# Altium schematic sheet kmd-foc[1].SchDoc(kmd-foc[1])
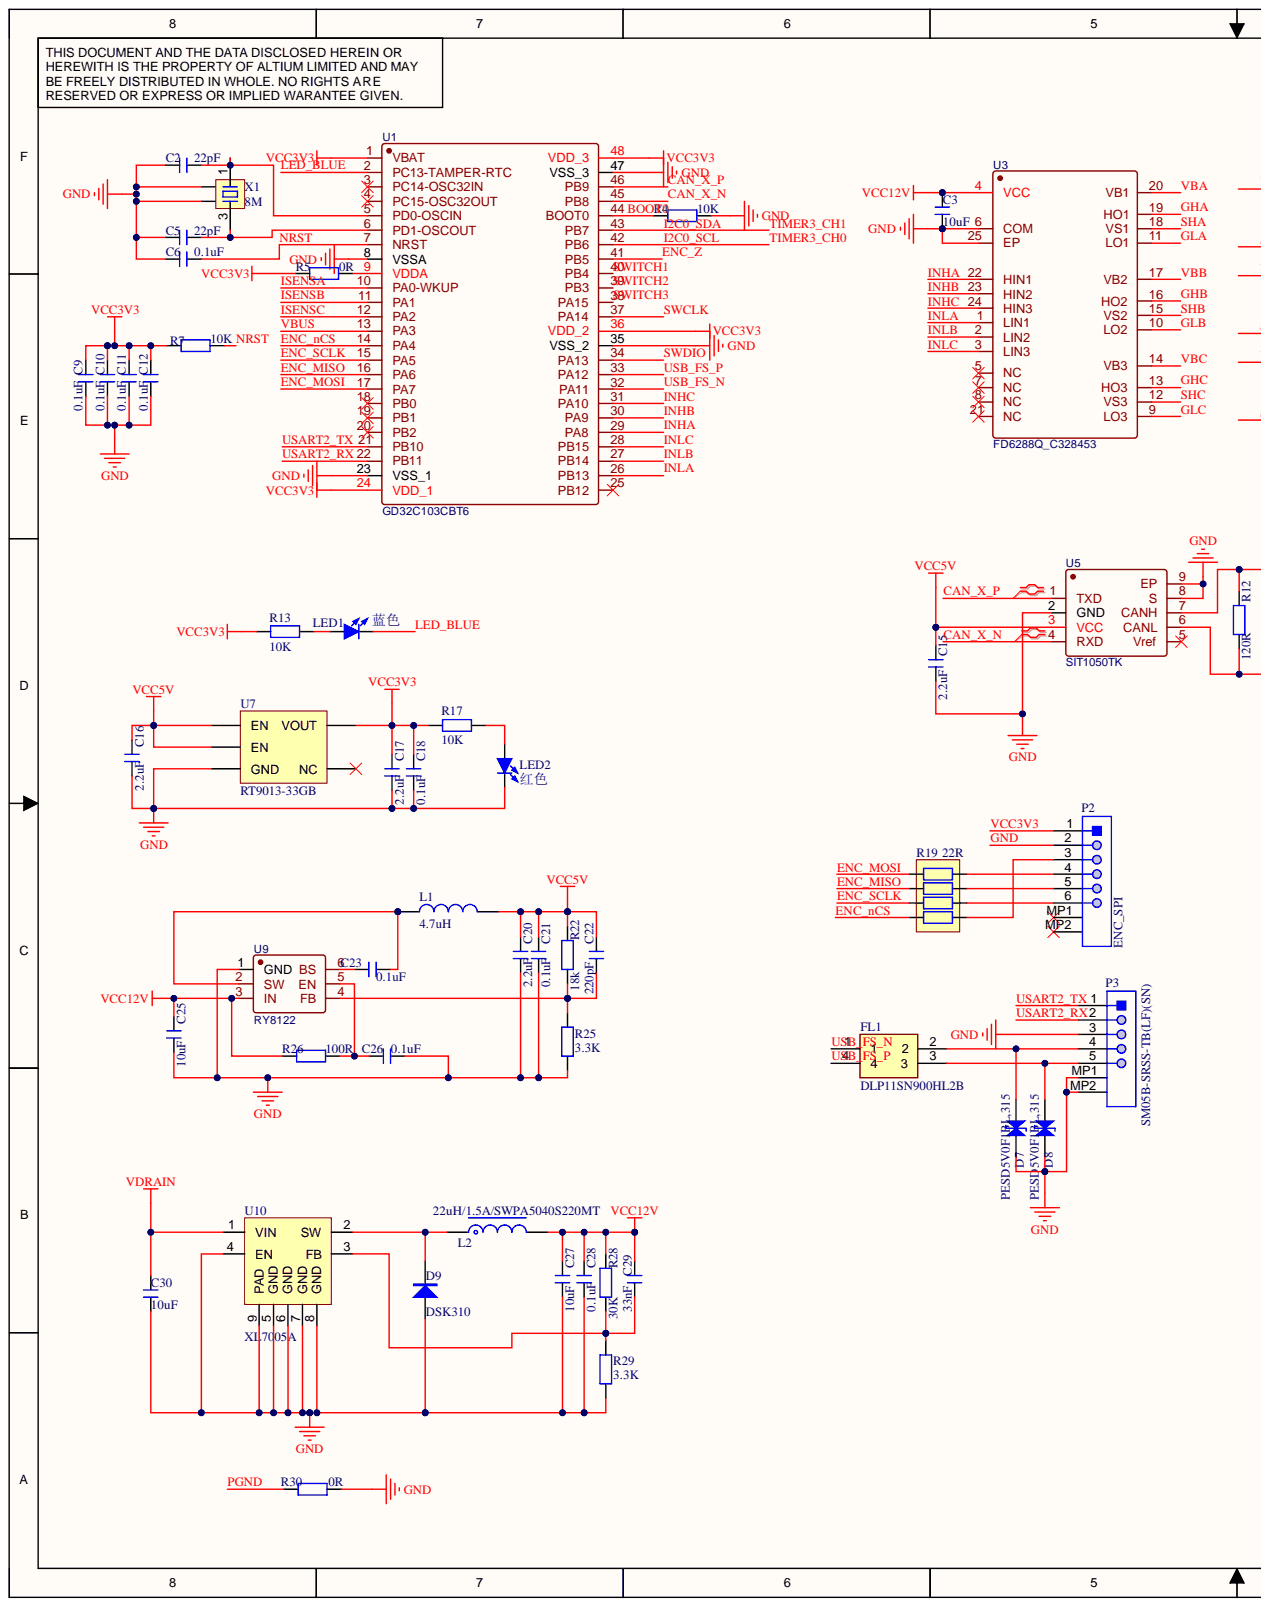
[diagram p0: kmd-foc[1].SchDoc, left]
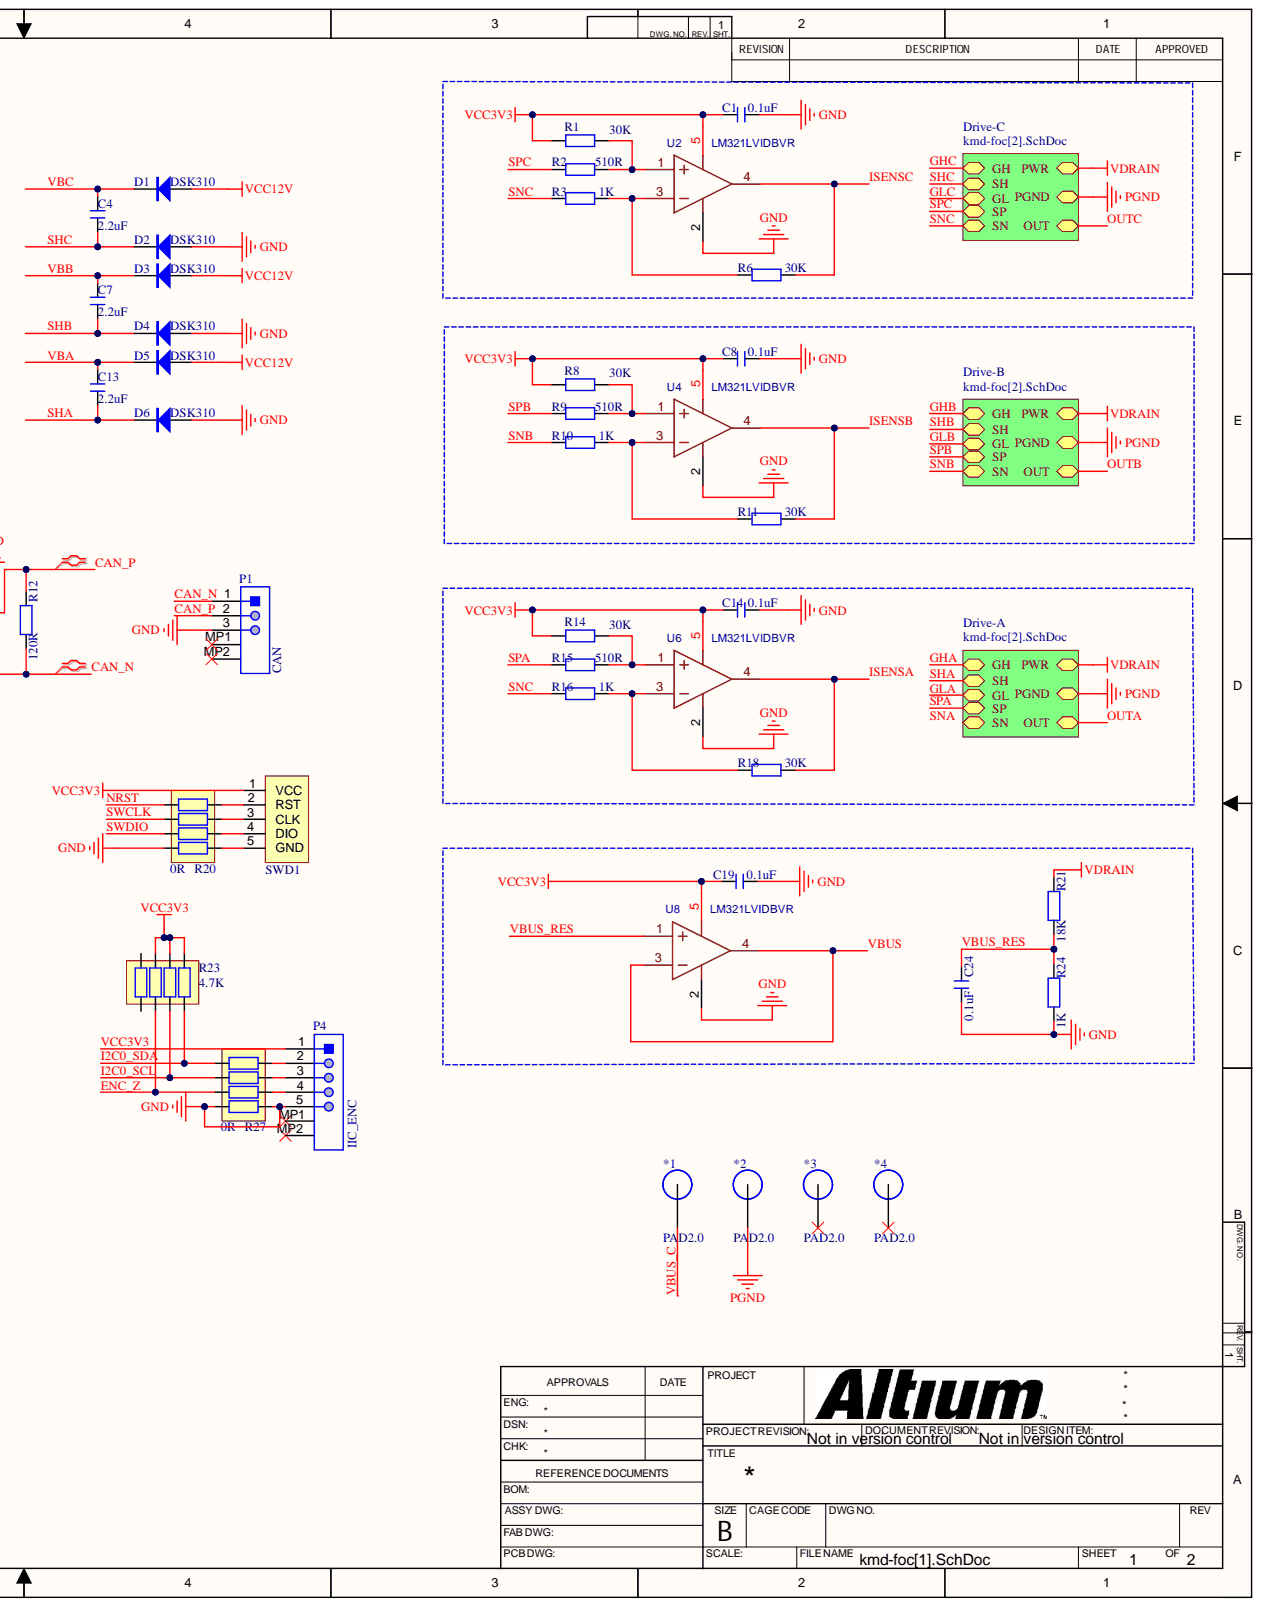
[diagram p1: kmd-foc[1].SchDoc, right]

## Parts
*1  PAD2.0  [PAD2.0]
*2  PAD2.0  [PAD2.0]
*3  PAD2.0  [PAD2.0]
*4  PAD2.0  [PAD2.0]
C1  0.1uF  [C 0402_L]
C2  22pF  [C 0402_L]
C3  10uF  [C 0805_L]
C4  2.2uF  [C 0805_L]
C5  22pF  [C 0402_L]
C6  0.1uF  [C 0402_L]
C7  2.2uF  [C 0805_L]
C8  0.1uF  [C 0402_L]
C9  0.1uF  [C 0402_L]
C10  0.1uF  [C 0402_L]
C11  0.1uF  [C 0402_L]
C12  0.1uF  [C 0402_L]
C13  2.2uF  [C 0805_L]
C14  0.1uF  [C 0402_L]
C15  2.2uF  [C 0402_L]
C16  2.2uF  [C 0402_L]
C17  2.2uF  [C 0402_L]
C18  0.1uF  [C 0402_L]
C19  0.1uF  [C 0402_L]
C20  2.2uF  [C 0402_L]
C21  0.1uF  [C 0402_L]
C22  220pF  [C 0402_L]
C23  0.1uF  [C 0402_L]
C24  0.1uF  [C 0402_L]
C25  10uF  [C 0805_L]
C26  0.1uF  [C 0402_L]
C27  10uF  [C 0805_L]
C28  0.1uF  [C 0805_L]
C29  33nF  [C 0402_L]
C30  10uF  [C 0805_L]
D1  DSK310  [SOD-123]
D2  DSK310  [SOD-123]
D3  DSK310  [SOD-123]
D4  DSK310  [SOD-123]
D5  DSK310  [SOD-123]
D6  DSK310  [SOD-123]
D7  PESD5V0F1BL,315  [SOD-882_L1.0-W0.6-BI]
D8  PESD5V0F1BL,315  [SOD-882_L1.0-W0.6-BI]
D9  DSK310  [SOD-123]
FL1  DLP11SN900HL2B  [DLP11SA350HL2B]
L1  4.7uH  [L 1210]
L2  22uH/1.5A/SWPA5040S220MT  [CD43]
LED1  蓝色  [LED 0805B]
LED2  红色  [LED 0805R]
P1  CAN  [JST-SM03B-SRSS-TB_V]
P2  ENC_SPI  [JST-SM06B-SRSS-TB_V]
P3  SM05B-SRSS-TB(LF)(SN)  [JST-SM05B-SRSS-TB_V]
P4  IIC_ENC  [JST-SM05B-SRSS-TB_V]
R1  30K  [R 0402_L]
R2  510R  [R 0402_L]
R3  1K  [R 0402_L]
R4  10K  [R 0402_L]
R5  0R  [R 0402_L]
R6  30K  [R 0402_L]
R7  10K  [R 0402_L]
R8  30K  [R 0402_L]
R9  510R  [R 0402_L]
R10  1K  [R 0402_L]
R11  30K  [R 0402_L]
R12  120R  [R 0402_L]
R13  10K  [R 0402_L]
R14  30K  [R 0402_L]
R15  510R  [R 0402_L]
R16  1K  [R 0402_L]
R17  10K  [R 0402_L]
R18  30K  [R 0402_L]
R19  22R  [RCA-8P4R-0402]
R20  0R  [RCA-8P4R-0402]
R21  18K  [R 0402_L]
R22  18k  [R 0402_L]
R23  4.7K  [RCA-8P4R-0402]
R24  1K  [R 0402_L]
R25  3.3K  [R 0402_L]
R26  100R  [R 0402_L]
R27  0R  [RCA-8P4R-0402]
R28  30K  [R 0402_L]
R29  3.3K  [R 0402_L]
R30  0R  [R 0402_L]
SWD1  HDR-1X5  [HDR-TH_5P-P1.27-V-M]  (value not drawn)
U1  GD32C103CBT6  [LQFP-48_L7.0-W7.0-P0.50-LS9.0-BL]
U2  LM321LVIDBVR  [SOT-23-5_L3.0-W1.7-P0.95-LS2.8-BL]
U3  FD6288Q_C328453  [QFN-24_L4.0-W4.0-P0.50-BL-EP2.6]
U4  LM321LVIDBVR  [SOT-23-5_L3.0-W1.7-P0.95-LS2.8-BL]
U5  SIT1050TK  [TDFN-8_L3.0-W3.0-P0.65-BL-EP]
U6  LM321LVIDBVR  [SOT-23-5_L3.0-W1.7-P0.95-LS2.8-BL]
U7  RT9013-33GB  [SOT23-5M]
U8  LM321LVIDBVR  [SOT-23-5_L3.0-W1.7-P0.95-LS2.8-BL]
U9  RY8122  [SOT-23-6_L2.9-W1.6-P0.95-LS2.8-BR]
U10  XL7005A  [SOP-8_EP_150mil]
X1  8M  [OSC 3225-4P]

## Nets
VCC5V: C15.1 C16.2 C20.2 C21.2 C22.1 L1.2 R22.2 U5.3(VCC) U7.1(EN) U7.3(EN)
VCC12V: C3.2 C25.2 C27.2 C28.2 C29.1 D1.1 D3.1 D5.1 L2.2 R26.2 R28.2 U3.4(VCC) U9.3(IN)
VDRAIN: C30.2 R21.2 U10.1(VIN)
~{}: R3.1 R16.1
BOOT0: R4.1 U1.44(BOOT0)
CAN_N: P1.1 R12.2 U5.6(CANL)
CAN_P: P1.2 R12.1 U5.7(CANH)
CAN_X_N: U1.45(PB8) U5.4(RXD)
CAN_X_P: U1.46(PB9) U5.1(TXD)
ENC_MISO: R19.7 U1.16(PA6)
ENC_MOSI: R19.8 U1.17(PA7)
ENC_nCS: R19.5 U1.14(PA4)
ENC_SCLK: R19.6 U1.15(PA5)
ENC_Z: R23.2 R27.3 U1.41(PB5)
GHA: U3.19(HO1)
GHB: U3.16(HO2)
GHC: U3.13(HO3)
GLA: U3.11(LO1)
GLB: U3.10(LO2)
GLC: U3.9(LO3)
GND: C1.2 C2.1 C3.1 C5.1 C6.2 C8.2 C9.2 C10.2 C11.2 C12.2 C14.2 C15.2 C16.1 C17.1 C18.1 C19.2 C20.1 C21.1 C24.2 C25.1 C26.2 C27.1 C28.1 C30.1 D2.1 D4.1 D6.1 D7.2 D8.2 D9.1 LED2.2 P1.3 P2.2 P3.3 P3.MP1 P3.MP2 P4.5 R4.2 R20.4 R24.1 R25.1 R27.4 R27.5 R29.1 R30.2 U1.8(VSSA) U1.23(VSS_1) U1.35(VSS_2) U1.47(VSS_3) U2.2 U3.6(COM) U3.25(EP) U4.2 U5.2(GND) U5.8(S) U5.9(EP) U6.2 U7.2(GND) U8.2 U9.1(GND) U10.4(EN) U10.5(GND) U10.6(GND) U10.7(GND) U10.8(GND) U10.9(PAD) X1.2 X1.4
I2C0_SCL: R23.3 R27.2 U1.42(PB6)
I2C0_SDA: R23.4 R27.1 U1.43(PB7)
INHA: U1.29(PA8) U3.22(HIN1)
INHB: U1.30(PA9) U3.23(HIN2)
INHC: U1.31(PA10) U3.24(HIN3)
INLA: U1.26(PB13) U3.1(LIN1)
INLB: U1.27(PB14) U3.2(LIN2)
INLC: U1.28(PB15) U3.3(LIN3)
ISENSA: R18.2 U1.10(PA0-WKUP) U6.4
ISENSB: R11.2 U1.11(PA1) U4.4
ISENSC: R6.2 U1.12(PA2) U2.4
LED_BLUE: LED1.2 U1.2(PC13-TAMPER-RTC)
NRST: C6.1 R7.1 R20.1 U1.7(NRST)
PGND: *2.0 R30.1
SHA: C13.1 D6.2 U3.18(VS1)
SHB: C7.1 D4.2 U3.15(VS2)
SHC: C4.1 D2.2 U3.12(VS3)
SNB: R10.1
SPA: R15.1
SPB: R9.1
SPC: R2.1
SWCLK: R20.2 U1.37(PA14)
SWDIO: R20.3 U1.34(PA13)
SWITCH1: U1.40(PB4)
SWITCH2: U1.39(PB3)
SWITCH3: U1.38(PA15)
USART2_RX: P3.2 U1.22(PB11)
USART2_TX: P3.1 U1.21(PB10)
USB_FS_N: FL1.1 U1.32(PA11)
USB_FS_P: FL1.4 U1.33(PA12)
VBA: C13.2 D5.2 U3.20(VB1)
VBB: C7.2 D3.2 U3.17(VB2)
VBC: C4.2 D1.2 U3.14(VB3)
VBUS: U1.13(PA3) U8.3 U8.4
VBUS_C: *1.0
VBUS_RES: C24.1 R21.1 R24.2 U8.1
VCC3V3: C1.1 C8.1 C9.1 C10.1 C11.1 C12.1 C14.1 C17.2 C18.2 C19.1 P2.1 P4.1 R1.2 R5.1 R7.2 R8.2 R13.1 R14.2 R17.2 R23.5 R23.6 R23.7 SWD1.1(VCC) U1.1(VBAT) U1.24(VDD_1) U1.36(VDD_2) U1.48(VDD_3) U2.5 U4.5 U6.5 U7.5(VOUT) U8.5
Net*3_0: *3.0
Net*4_0: *4.0
NetC2_2: C2.2 U1.5(PD0-OSCIN) X1.1
NetC5_2: C5.2 U1.6(PD1-OSCOUT) X1.3
NetC22_2: C22.2 R22.1 R25.2 U9.4(FB)
NetC23_1: C23.1 U9.6(BS)
NetC23_2: C23.2 L1.1 U9.2(SW)
NetC26_1: C26.1 R26.1 U9.5(EN)
NetC29_2: C29.2 R28.1 R29.2 U10.3(FB)
NetD7_1: D7.1 FL1.2 P3.4
NetD8_1: D8.1 FL1.3 P3.5
NetD9_2: D9.2 L2.1 U10.2(SW)
NetLED1_1: LED1.1 R13.2
NetLED2_1: LED2.1 R17.1
NetP1_MP1: P1.MP1
NetP1_MP2: P1.MP2
NetP2_3: P2.3 R19.4
NetP2_4: P2.4 R19.1
NetP2_5: P2.5 R19.2
NetP2_6: P2.6 R19.3
NetP2_MP1: P2.MP1
NetP2_MP2: P2.MP2
NetP4_2: P4.2 R27.8
NetP4_3: P4.3 R27.7
NetP4_4: P4.4 R27.6
NetP4_MP1: P4.MP1
NetP4_MP2: P4.MP2
NetR1_1: R1.1 R2.2 U2.1
NetR3_2: R3.2 R6.1 U2.3
NetR5_2: R5.2 U1.9(VDDA)
NetR8_1: R8.1 R9.2 U4.1
NetR10_2: R10.2 R11.1 U4.3
NetR14_1: R14.1 R15.2 U6.1
NetR16_2: R16.2 R18.1 U6.3
NetR20_5: R20.5 SWD1.5(GND)
NetR20_6: R20.6 SWD1.4(DIO)
NetR20_7: R20.7 SWD1.3(CLK)
NetR20_8: R20.8 SWD1.2(RST)
NetR23_1: R23.1
NetR23_8: R23.8
NetU1_3: U1.3(PC14-OSC32IN)
NetU1_4: U1.4(PC15-OSC32OUT)
NetU1_18: U1.18(PB0)
NetU1_19: U1.19(PB1)
NetU1_20: U1.20(PB2)
NetU1_25: U1.25(PB12)
NetU3_5: U3.5(NC)
NetU3_7: U3.7(NC)
NetU3_8: U3.8(NC)
NetU3_21: U3.21(NC)
NetU5_5: U5.5(Vref)
NetU7_4: U7.4(NC)
OUTA:
OUTB:
OUTC:
SN:
SNA:

## Library data of the parts
C1-C30 = C: 贴片电容; Component Kind: Standard; Library Name: 0.1 - 电阻-电容-电感.SchLib
D1-D6 D9 = 1N4007: 整流二极管; Component Kind: Standard; Library Name: 0.2 -  二极管-整流桥.SchLib
D7 D8 = PESD5V0F1BL,315: PESD5V0F1BL,315、ESD二极管、5.5V; Component Kind: Standard; Library Name: 0.2 -  二极管-整流桥.SchLib
FL1 = DLP11SN900HL2B: Filter; Manufacturer_Name: Murata Electronics; Component Kind: Standard; Datasheet Link: https://www.murata.com/en-us/products/productdetail?partno=DLP11SN900HL2%23; Height: 0.92mm; Manufacturer_Part_Number: DLP11SN900HL2B; Mouser Part Number: 81-DLP11SN900HL2B; Mouser Price/Stock: https://www.mouser.co.uk/ProductDetail/Murata-Electronics/DLP11SN900HL2B?qs=%2F%252BYoplLUB5ikZtvOhZcMEg%3D%3D; Library Name: vSeasky.SchLib
L1 = L: 小功率贴片电感; Component Kind: Standard; Library Name: 0.1 - 电阻-电容-电感.SchLib
L2 = L-SMD: CD系列贴片线绕功率电感; Component Kind: Standard; Library Name: 0.1 - 电阻-电容-电感.SchLib
LED1 LED2 = LED-SMD: 贴片LED; Component Kind: Standard; Library Name: 0.3 - LED发光二极管.SchLib
P1 = SM03B-SRSS-TB(LF)(SN): Male Header, Pitch 1 mm, 1 x 3 Position, Height 2.9 mm, -25 to 85 degC, RoHS, Tape and Reel; Manufacturer: JST; No of Positions: 3; Pitch: 1mm; Style/Orientation: RightAngleHeader/Plug; Component Kind: Standard; Datasheet URL: http://www.jst-mfg.com/product/pdf/eng/eSR.pdf; Datasheet Version: 05/2016; Library Name: JST SH Connector.IntLib; Manufacturer URL: http://www.jst.com/home2.html; Mounting Technology: SurfaceMount; Package Description: Male Header, Pitch 1 mm, 1 x 3 Position, Height 2.9 mm; Package Reference: SM03B-SRSS-TB; Termination: Crimp; Type: ShroudedHeader
P2 = SM06B-SRSS-TB(LF)(SN): Male Header, Pitch 1 mm, 1 x 6 Position, Height 2.9 mm, -25 to 85 degC, RoHS, Tape and Reel; Manufacturer: JST; No of Positions: 6; Pitch: 1mm; Style/Orientation: RightAngleHeader/Plug; Component Kind: Standard; Datasheet URL: http://www.jst-mfg.com/product/pdf/eng/eSR.pdf; Datasheet Version: 05/2016; Library Name: JST SH Connector.IntLib; Manufacturer URL: http://www.jst.com/home2.html; Mounting Technology: SurfaceMount; Package Description: Male Header, Pitch 1 mm, 1 X 6 Position, Height 2.9 mm; Package Reference: SM06B-SRSS-TB(LF)(SN); Termination: Solder; Type: ShroudedHeader
P3 P4 = SM05B-SRSS-TB(LF)(SN): Male Header, Pitch 1 mm, 1 x 5 Position, Height 2.9 mm, -25 to 85 degC, RoHS, Tape and Reel; Manufacturer: JST; No of Positions: 5; Pitch: 1mm; Style/Orientation: RightAngleHeader/Plug; Component Kind: Standard; Datasheet URL: http://www.jst-mfg.com/product/pdf/eng/eSR.pdf; Datasheet Version: 05/2016; Library Name: JST SH Connector.IntLib; Manufacturer URL: http://www.jst.com/home2.html; Mounting Technology: SurfaceMount; Package Description: Male Header, Pitch 1 mm, 1 x 5 Position, Height 2.9 mm; Package Reference: SM05B-SRSS-TB(LF)(SN); Termination: Crimp; Type: ShroudedHeader
R1-R18 R21 R22 R24-R26 R28-R30 = R: 贴片电阻; Component Kind: Standard; Library Name: 0.1 - 电阻-电容-电感.SchLib
R19 R20 R23 R27 = R-8P4R: 贴片排阻; Component Kind: Standard; Library Name: 0.1 - 电阻-电容-电感.SchLib
SWD1 = SWD: 5P接插件; Component Kind: Standard; Library Name: mx_pcb_library.SchLib
U1 = GD32C103CBT6: BOM_Manufacturer: null; Contributor: LCSC; BOM_JLCPCB Part Class: Extended Part; BOM_Manufacturer Part: GD32C103CBT6; BOM_Supplier: LCSC; BOM_Supplier Part: C2885643; Component Kind: Standard; Library Name: mx_stm32_lib.SchLib; spicePre: U; spiceSymbolName: GD32C103CBT6
U2 U4 U6 U8 = LM321LVIDBVR: BOM_Manufacturer: Texas Instruments; Contributor: LCSC; BOM_JLCPCB Part Class: Extended Part; BOM_Manufacturer Part: LM321LVIDBVR; BOM_Supplier: LCSC; BOM_Supplier Part: C471859; Component Kind: Standard; Library Name: mx_pcb_library.SchLib; spicePre: U; spiceSymbolName: LM321LVIDBVR
U3 = FD6288Q_C328453: BOM_Manufacturer: Fortior Tech; Contributor: LCSC; BOM_JLCPCB Part Class: Extended Part; BOM_Manufacturer Part: FD6288Q; BOM_Supplier: LCSC; BOM_Supplier Part: C328453; Component Kind: Standard; Library Name: mx_pcb_library.SchLib; spicePre: U; spiceSymbolName: FD6288Q_C328453
U5 = SIT1050TK: BOM_Manufacturer: SIT; Contributor: LCSC; BOM_JLCPCB Part Class: Extended Part; BOM_Manufacturer Part: SIT1050TK; BOM_Supplier: LCSC; BOM_Supplier Part: C427444; Component Kind: Standard; Library Name: mx_pcb_library.SchLib; spicePre: U; spiceSymbolName: SIT1050TK
U9 = RY8122: BOM_Manufacturer: RYCHIP Semiconductor Inc.; Contributor: LCSC; BOM_JLCPCB Part Class: Extended Part; BOM_Manufacturer Part: RY8122; BOM_Supplier: LCSC; BOM_Supplier Part: C370758; Component Kind: Standard; Library Name: mx_pcb_library.SchLib; spicePre: U; spiceSymbolName: RY8122
X1 = XTAL-4P: 4脚无源晶振; Component Kind: Standard; Library Name: 2.0 - 保险丝-晶振-光耦.SchLib
*1-*4 = PAD2.0: Component Kind: Standard; Library Name: mx_pcb_library.SchLib
U7 = RT9013-33GB: Component Kind: Standard; Library Name: mx_pcb_library.SchLib
U10 = XL7005A: Component Kind: Standard; Library Name: mx_pcb_library.SchLib
